# Altium schematic sheet Sheet_connectors.SchDoc
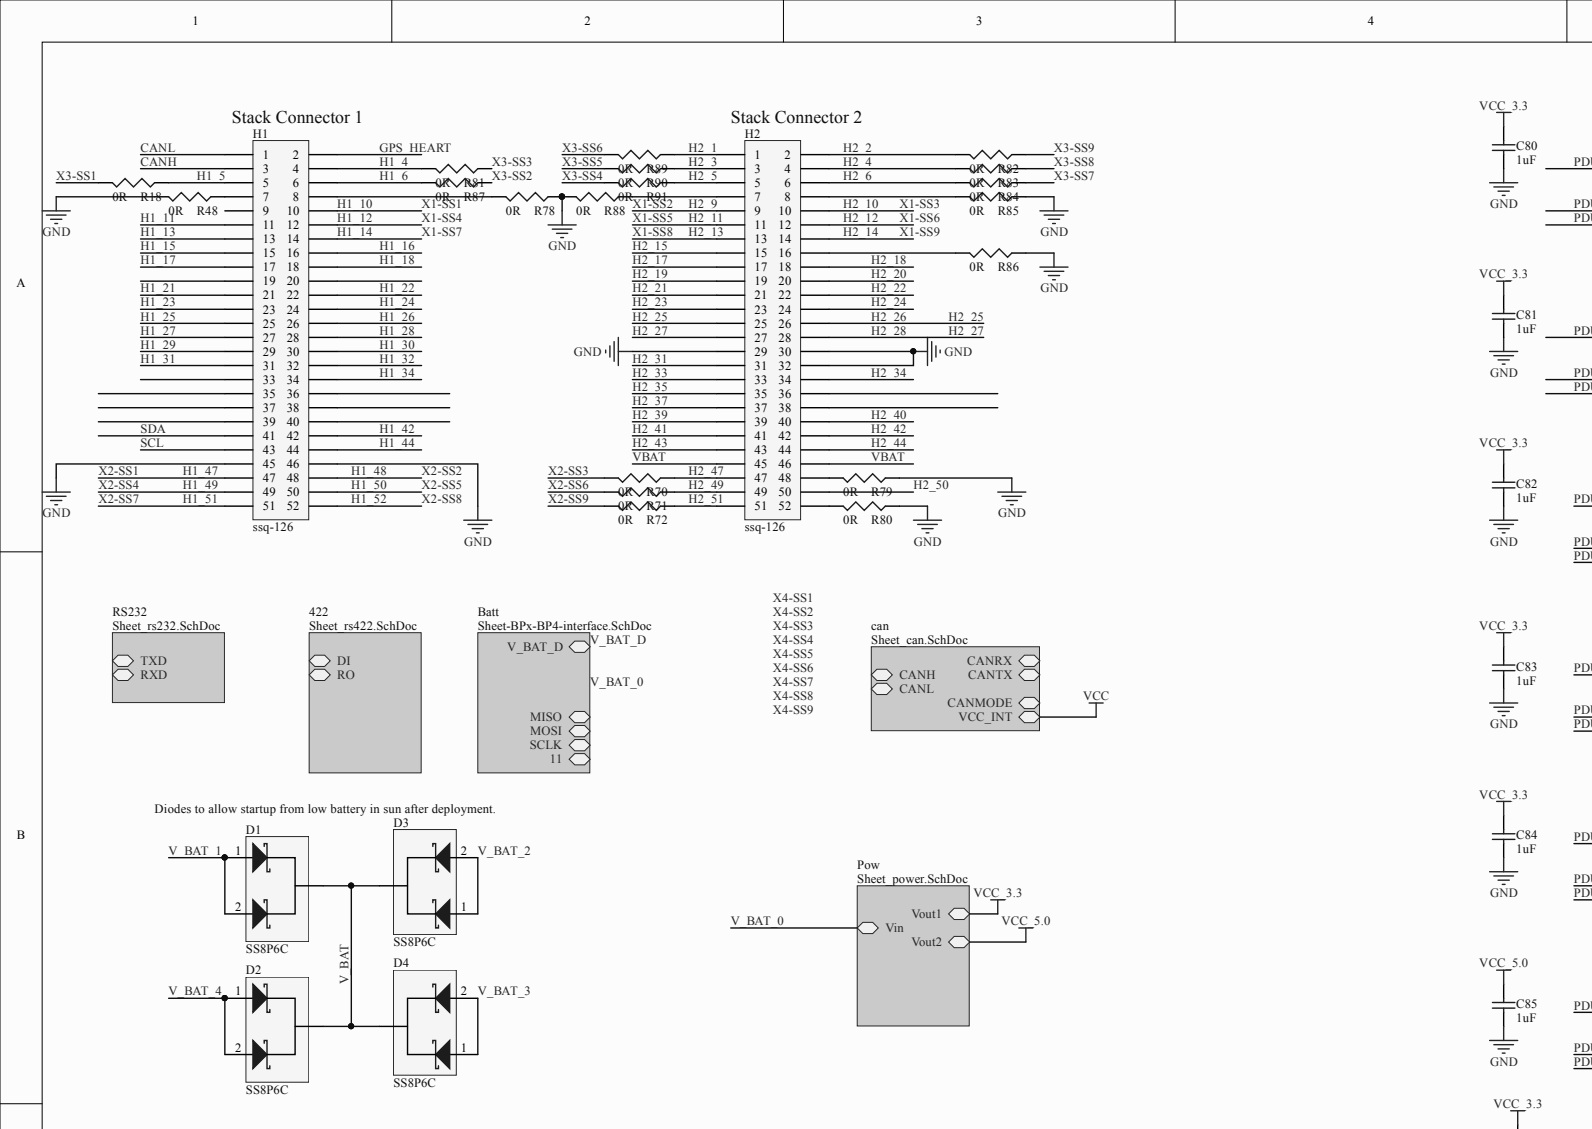
[diagram p0: Sheet_connectors.SchDoc, top left]
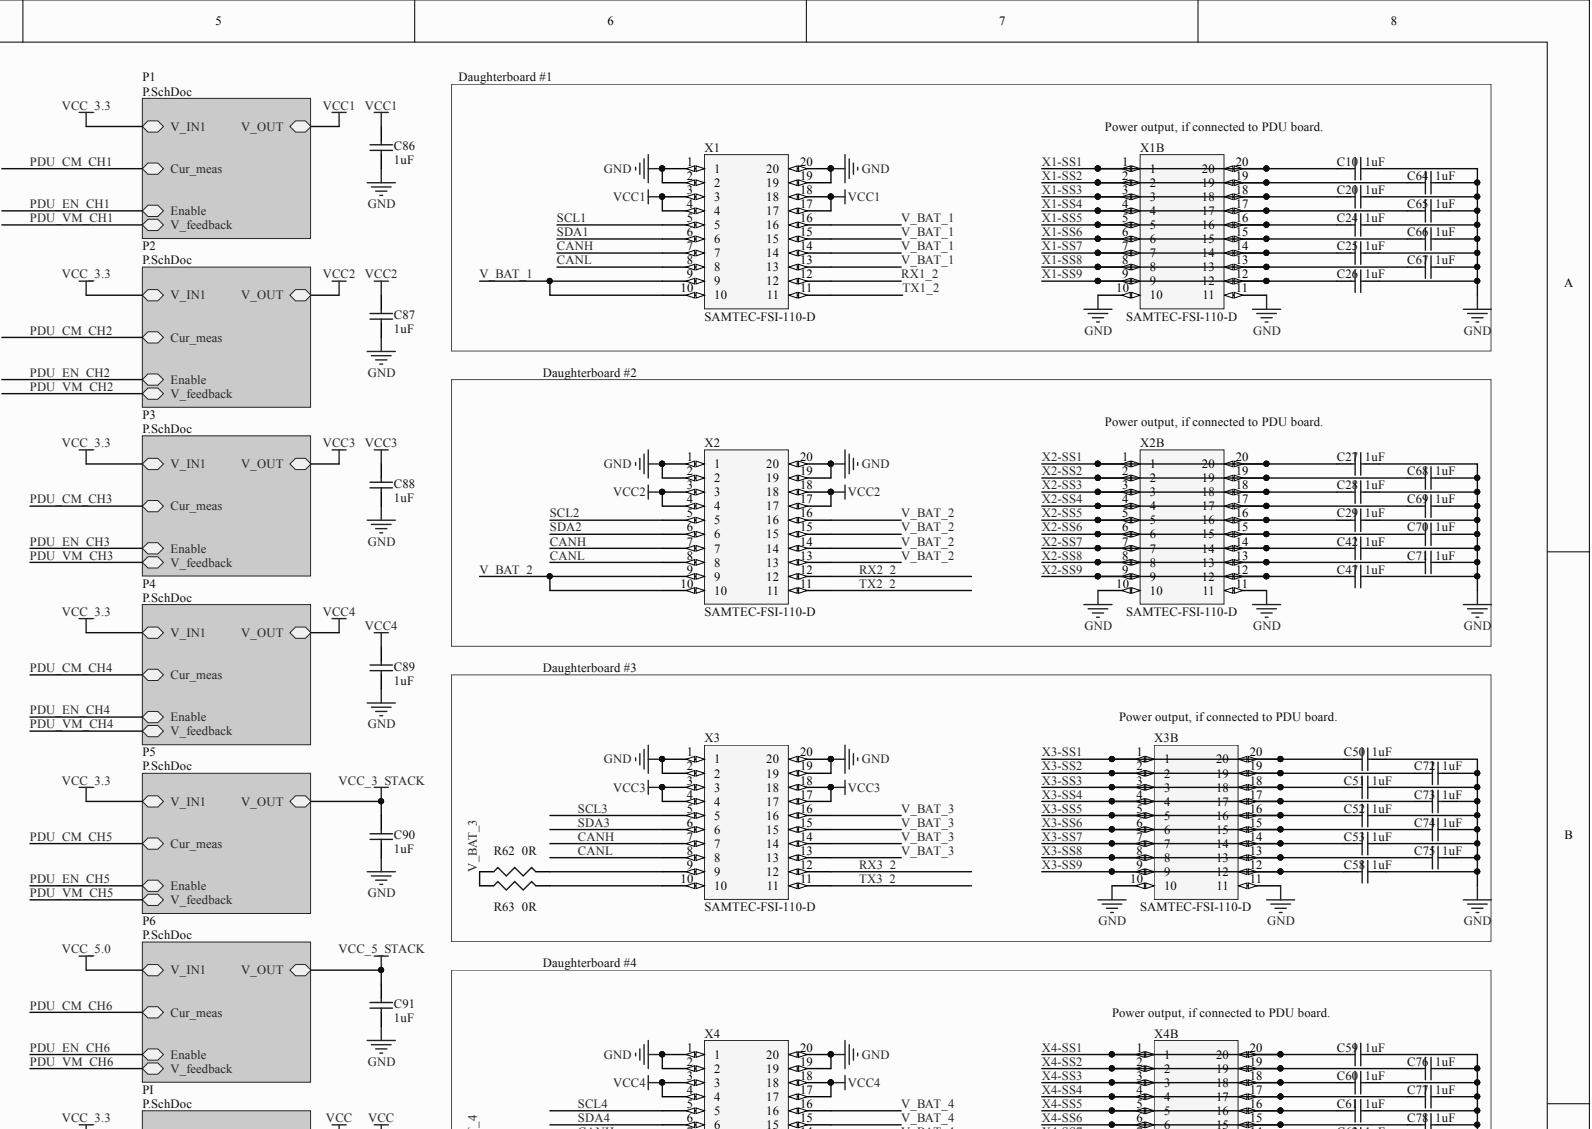
[diagram p1: Sheet_connectors.SchDoc, top right]
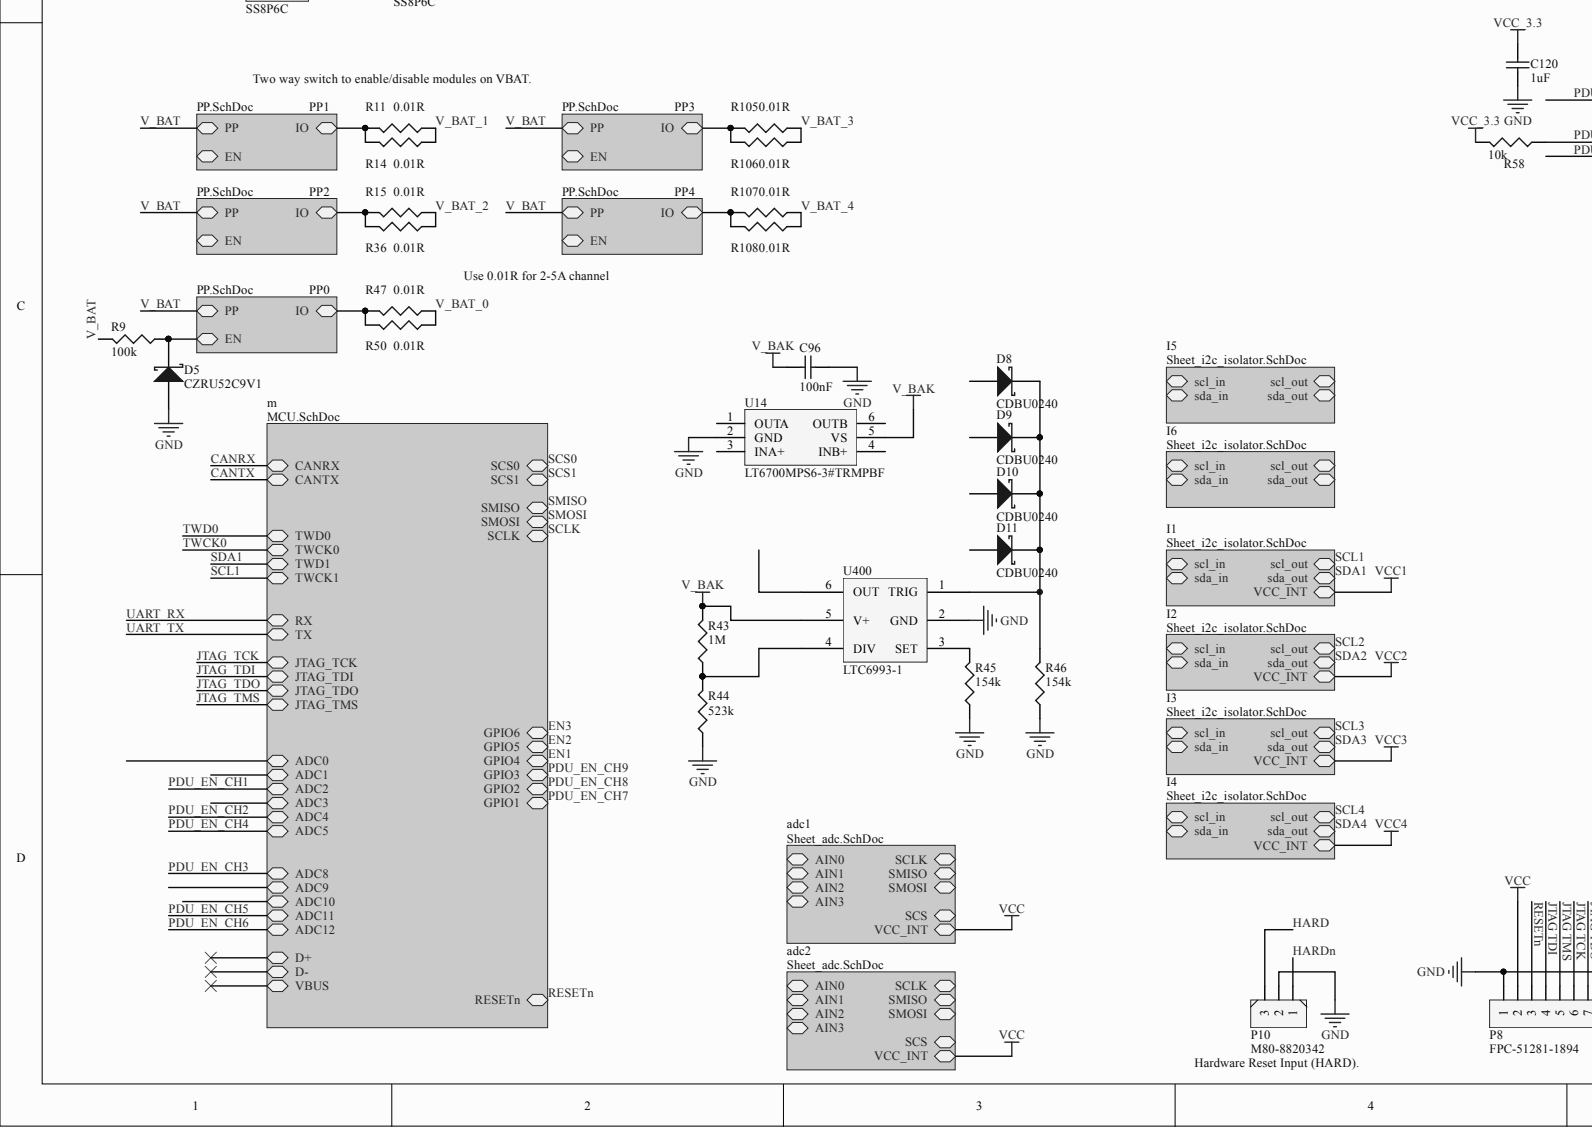
[diagram p2: Sheet_connectors.SchDoc, bottom left]
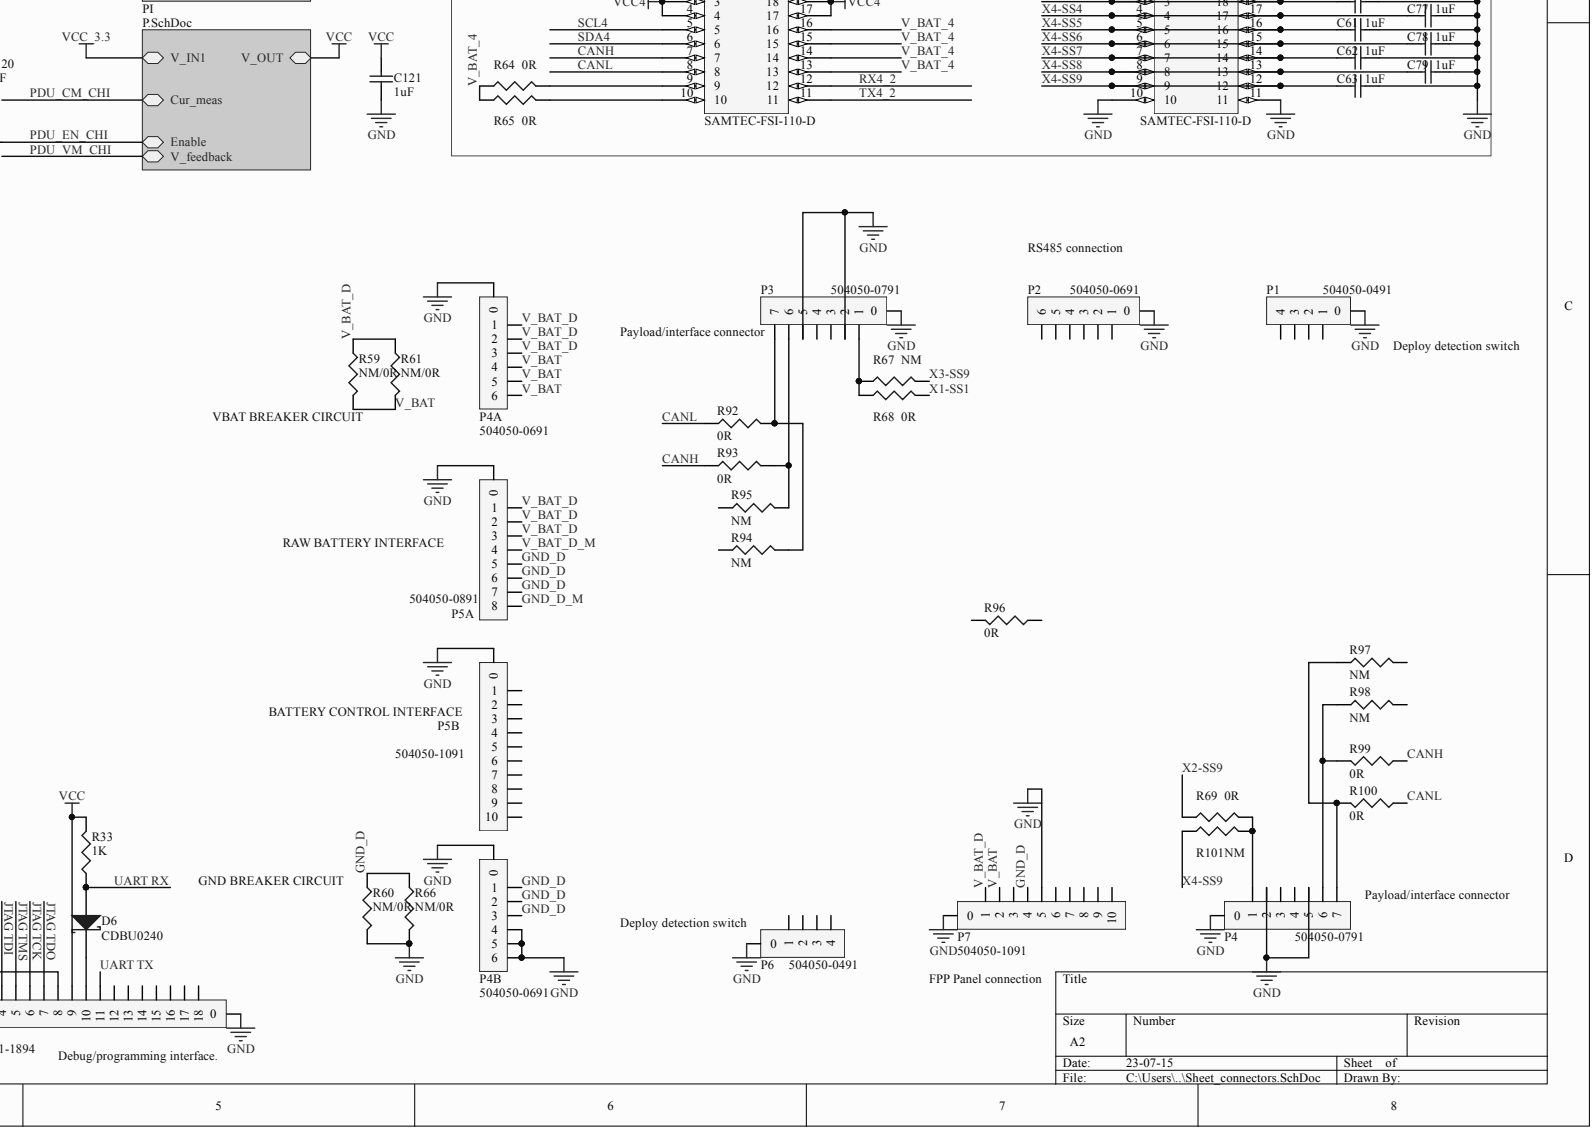
[diagram p3: Sheet_connectors.SchDoc, bottom right]

## Parts
C10  value 1uF  [0805]  (hidden comment: Cap Semi)
C20  value 1uF  [0805]  (hidden comment: Cap Semi)
C24  value 1uF  [0805]  (hidden comment: Cap Semi)
C25  value 1uF  [0805]  (hidden comment: Cap Semi)
C26  value 1uF  [0805]  (hidden comment: Cap Semi)
C27  value 1uF  [0805]  (hidden comment: Cap Semi)
C28  value 1uF  [0805]  (hidden comment: Cap Semi)
C29  value 1uF  [0805]  (hidden comment: Cap Semi)
C42  value 1uF  [0805]  (hidden comment: Cap Semi)
C47  value 1uF  [0805]  (hidden comment: Cap Semi)
C50  value 1uF  [0805]  (hidden comment: Cap Semi)
C51  value 1uF  [0805]  (hidden comment: Cap Semi)
C52  value 1uF  [0805]  (hidden comment: Cap Semi)
C53  value 1uF  [0805]  (hidden comment: Cap Semi)
C58  value 1uF  [0805]  (hidden comment: Cap Semi)
C59  value 1uF  [0805]  (hidden comment: Cap Semi)
C60  value 1uF  [0805]  (hidden comment: Cap Semi)
C61  value 1uF  [0805]  (hidden comment: Cap Semi)
C62  value 1uF  [0805]  (hidden comment: Cap Semi)
C63  value 1uF  [0805]  (hidden comment: Cap Semi)
C64  value 1uF  [0805]  (hidden comment: Cap Semi)
C65  value 1uF  [0805]  (hidden comment: Cap Semi)
C66  value 1uF  [0805]  (hidden comment: Cap Semi)
C67  value 1uF  [0805]  (hidden comment: Cap Semi)
C68  value 1uF  [0805]  (hidden comment: Cap Semi)
C69  value 1uF  [0805]  (hidden comment: Cap Semi)
C70  value 1uF  [0805]  (hidden comment: Cap Semi)
C71  value 1uF  [0805]  (hidden comment: Cap Semi)
C72  value 1uF  [0805]  (hidden comment: Cap Semi)
C73  value 1uF  [0805]  (hidden comment: Cap Semi)
C74  value 1uF  [0805]  (hidden comment: Cap Semi)
C75  value 1uF  [0805]  (hidden comment: Cap Semi)
C76  value 1uF  [0805]  (hidden comment: Cap Semi)
C77  value 1uF  [0805]  (hidden comment: Cap Semi)
C78  value 1uF  [0805]  (hidden comment: Cap Semi)
C79  value 1uF  [0805]  (hidden comment: Cap Semi)
C80  value 1uF  [0805]  (hidden comment: Cap Semi)
C81  value 1uF  [0805]  (hidden comment: Cap Semi)
C82  value 1uF  [0805]  (hidden comment: Cap Semi)
C83  value 1uF  [0805]  (hidden comment: Cap Semi)
C84  value 1uF  [0805]  (hidden comment: Cap Semi)
C85  value 1uF  [0805]  (hidden comment: Cap Semi)
C86  value 1uF  [0805]  (hidden comment: Cap Semi)
C87  value 1uF  [0805]  (hidden comment: Cap Semi)
C88  value 1uF  [0805]  (hidden comment: Cap Semi)
C89  value 1uF  [0805]  (hidden comment: Cap Semi)
C90  value 1uF  [0805]  (hidden comment: Cap Semi)
C91  value 1uF  [0805]  (hidden comment: Cap Semi)
C96  value 100nF  [0402]  (hidden comment: Cap Semi)
C120  value 1uF  [0805]  (hidden comment: Cap Semi)
C121  value 1uF  [0805]  (hidden comment: Cap Semi)
D1  SS8P6C  [TO-227A(smpc)]
D2  SS8P6C  [TO-227A(smpc)]
D3  SS8P6C  [TO-227A(smpc)]
D4  SS8P6C  [TO-227A(smpc)]
D5  CZRU52C9V1  [CD1608-0603]
D6  CDBU0240  [CD1608-0603]
D8  CDBU0240  [CD1608-0603]
D9  CDBU0240  [CD1608-0603]
D10  CDBU0240  [CD1608-0603]
D11  CDBU0240  [CD1608-0603]
H1  ssq-126  [footprint 2x26 stik]
H2  ssq-126  [footprint 2x26 stik]
P1  504050-0491  [pico-lock-04]
P2  504050-0691  [pico-lock-06]
P3  504050-0791  [pico-lock-07]
P4  504050-0791  [pico-lock-07]
P4A  504050-0691  [pico-lock-06]
P4B  504050-0691  [pico-lock-06]
P5A  504050-0891  [pico-lock-08-W]
P5B  504050-1091  [pico-lock-10]
P6  504050-0491  [pico-lock-04]
P7  504050-1091  [pico-lock-10]
P8  FPC-51281-1894  [51281-1894]
P10  M80-8820342  [M80-8820342]
R9  value 100k  [0402]  (hidden comment: Res3)
R11  value 0.01R  [0603]  (hidden comment: Res3)
R14  value 0.01R  [0603]  (hidden comment: Res3)
R15  value 0.01R  [0603]  (hidden comment: Res3)
R18  value 0R  [0505]  (hidden comment: Res3)
R33  value 1K  [0402]  (hidden comment: Res3)
R36  value 0.01R  [0603]  (hidden comment: Res3)
R43  value 1M  [0402]  (hidden comment: Res3)
R44  value 523k  [0402]  (hidden comment: Res3)
R45  value 154k  [0402]  (hidden comment: Res3)
R46  value 154k  [0402]  (hidden comment: Res3)
R47  value 0.01R  [0603]  (hidden comment: Res3)
R48  value 0R  [0505]  (hidden comment: Res3)
R50  value 0.01R  [0603]  (hidden comment: Res3)
R58  value 10k  [0402]  (hidden comment: Res3)
R59  value NM/0R  [0505]  (hidden comment: Res3)
R60  value NM/0R  [0505]  (hidden comment: Res3)
R61  value NM/0R  [0505]  (hidden comment: Res3)
R62  value 0R  [0505]  (hidden comment: Res3)
R63  value 0R  [0505]  (hidden comment: Res3)
R64  value 0R  [0505]  (hidden comment: Res3)
R65  value 0R  [0505]  (hidden comment: Res3)
R66  value NM/0R  [0505]  (hidden comment: Res3)
R67  value NM  [0505]  (hidden comment: Res3)
R68  value 0R  [0505]  (hidden comment: Res3)
R69  value 0R  [0505]  (hidden comment: Res3)
R70  Res3  [0505]  (value not drawn)
R71  value 0R  [0505]  (hidden comment: Res3)
R72  value 0R  [0505]  (hidden comment: Res3)
R78  value 0R  [0505]  (hidden comment: Res3)
R79  value 0R  [0505]  (hidden comment: Res3)
R80  value 0R  [0505]  (hidden comment: Res3)
R81  value 0R  [0505]  (hidden comment: Res3)
R82  value 0R  [0505]  (hidden comment: Res3)
R83  value 0R  [0505]  (hidden comment: Res3)
R84  value 0R  [0505]  (hidden comment: Res3)
R85  value 0R  [0505]  (hidden comment: Res3)
R86  value 0R  [0505]  (hidden comment: Res3)
R87  value 0R  [0505]  (hidden comment: Res3)
R88  value 0R  [0505]  (hidden comment: Res3)
R89  value 0R  [0505]  (hidden comment: Res3)
R90  value 0R  [0505]  (hidden comment: Res3)
R91  value 0R  [0505]  (hidden comment: Res3)
R92  value 0R  [0402]  (hidden comment: Res3)
R93  value 0R  [0402]  (hidden comment: Res3)
R94  value NM  [0402]  (hidden comment: Res3)
R95  value NM  [0402]  (hidden comment: Res3)
R96  value 0R  [0402]  (hidden comment: Res3)
R97  value NM  [0402]  (hidden comment: Res3)
R98  value NM  [0402]  (hidden comment: Res3)
R99  value 0R  [0402]  (hidden comment: Res3)
R100  value 0R  [0402]  (hidden comment: Res3)
R101  value NM  [0505]  (hidden comment: Res3)
R105  value 0.01R  [0603]  (hidden comment: Res3)
R106  value 0.01R  [0603]  (hidden comment: Res3)
R107  value 0.01R  [0603]  (hidden comment: Res3)
R108  value 0.01R  [0603]  (hidden comment: Res3)
U14  LT6700  PartNumber: LT6700MPS6-3#TRMPBF  [SOT23-6]
U400  LTC6993-1  [SOT95P280X100-6N]
X1  SAMTEC-FSI-110-D  [FSI-110-03-G-D-AD]
X1B  SAMTEC-FSI-110-D  [FSI-110-03-G-D-AD]
X2  SAMTEC-FSI-110-D  [FSI-110-03-G-D-AD]
X2B  SAMTEC-FSI-110-D  [FSI-110-03-G-D-AD]
X3  SAMTEC-FSI-110-D  [FSI-110-03-G-D-AD]
X3B  SAMTEC-FSI-110-D  [FSI-110-03-G-D-AD]
X4  SAMTEC-FSI-110-D  [FSI-110-03-G-D-AD]
X4B  SAMTEC-FSI-110-D  [FSI-110-03-G-D-AD]

## Nets
GND: C10.1 C20.1 C24.1 C25.1 C26.1 C27.1 C28.1 C29.1 C42.1 C47.1 C50.1 C51.1 C52.1 C53.1 C58.1 C59.1 C60.1 C61.1 C62.1 C63.1 C64.1 C65.1 C66.1 C67.1 C68.1 C69.1 C70.1 C71.1 C72.1 C73.1 C74.1 C75.1 C76.1 C77.1 C78.1 C79.1 C80.1 C81.1 C82.1 C83.1 C84.1 C85.1 C86.1 C87.1 C88.1 C89.1 C90.1 C91.1 C96.1 C120.1 C121.1 D5.1 H1.45 H1.46 H2.29 H2.30 H2.32 P1.0 P2.0 P3.0 P3.2 P3.5 P4.0 P4.2 P4.5 P4A.0 P4B.0 P4B.4 P4B.5 P4B.6 P5A.0 P5B.0 P6.0 P7.0 P7.5 P8.0 P8.1 P8.8 P10.2 R44.1 R45.1 R46.1 R48.2 R60.2 R66.2 R78.1 R79.1 R80.1 R85.1 R86.1 R88.2 U14.2(GND) U400.2(GND) X1.1 X1.2 X1.19 X1.20 X1B.10 X1B.11 X2.1 X2.2 X2.19 X2.20 X2B.10 X2B.11 X3.1 X3.2 X3.19 X3.20 X3B.10 X3B.11 X4.1 X4.2 X4.19 X4.20 X4B.10 X4B.11
V_BAK: C96.2 R43.2 U14.5(VS) U400.5(V+)
VCC: C121.2 P8.2 P8.9 R33.2
VCC1: C86.2 X1.3 X1.4 X1.17 X1.18
VCC2: C87.2 X2.3 X2.4 X2.17 X2.18
VCC3: C88.2 X3.3 X3.4 X3.17 X3.18
VCC4: C89.2 X4.3 X4.4 X4.17 X4.18
VCC_3.3: C80.2 C81.2 C82.2 C83.2 C84.2 C120.2 R58.2
VCC_3_STACK: C90.2
VCC_5.0: C85.2
VCC_5_STACK: C91.2
CANH: H1.3 R93.1 R99.1 X1.7 X2.7 X3.7 X4.7
CANL: H1.1 R92.1 R100.1 X1.8 X2.8 X3.8 X4.8
GND_D: P4B.1 P4B.2 P4B.3 P5A.5 P5A.6 P5A.7 P7.4 R60.1 R66.1
GND_D_M: P5A.8
GPS_HEART: H1.2
H1_4: H1.4 R81.2
H1_5: H1.5 R18.1
H1_6: H1.6 R87.2
H1_10: C10.2 H1.10 R68.2 X1B.1 X1B.20
H1_11: H1.11
H1_12: C65.2 H1.12 X1B.4 X1B.17
H1_13: H1.13
H1_14: C25.2 H1.14 X1B.7 X1B.14
H1_15: H1.15
H1_16: H1.16
H1_17: H1.17
H1_18: H1.18
H1_21: H1.21
H1_22: H1.22
H1_23: H1.23
H1_24: H1.24
H1_25: H1.25
H1_26: H1.26
H1_27: H1.27
H1_28: H1.28
H1_29: H1.29
H1_30: H1.30
H1_31: H1.31
H1_32: H1.32
H1_34: H1.34
H1_42: H1.42
H1_44: H1.44
H1_47: C27.2 H1.47 X2B.1 X2B.20
H1_48: C68.2 H1.48 X2B.2 X2B.19
H1_49: C69.2 H1.49 X2B.4 X2B.17
H1_50: C29.2 H1.50 X2B.5 X2B.16
H1_51: C42.2 H1.51 X2B.7 X2B.14
H1_52: C71.2 H1.52 X2B.8 X2B.13
H2_1: H2.1 R89.1
H2_2: H2.2 R82.2
H2_3: H2.3 R90.1
H2_4: H2.4 R83.2
H2_5: H2.5 R91.1
H2_6: H2.6 R84.2
H2_9: C64.2 H2.9 X1B.2 X1B.19
H2_10: C20.2 H2.10 X1B.3 X1B.18
H2_11: C24.2 H2.11 X1B.5 X1B.16
H2_12: C66.2 H2.12 X1B.6 X1B.15
H2_13: C67.2 H2.13 X1B.8 X1B.13
H2_14: C26.2 H2.14 X1B.9 X1B.12
H2_15: H2.15
H2_17: H2.17
H2_18: H2.18
H2_19: H2.19
H2_20: H2.20
H2_21: H2.21
H2_22: H2.22
H2_23: H2.23
H2_24: H2.24
H2_25: H2.25 H2.26
H2_27: H2.27 H2.28
H2_31: H2.31
H2_33: H2.33
H2_34: H2.34
H2_35: H2.35
H2_37: H2.37
H2_39: H2.39
H2_40: H2.40
H2_41: H2.41
H2_42: H2.42
H2_43: H2.43
H2_44: H2.44
H2_47: H2.47 R70.1
H2_49: H2.49 R71.1
H2_50: H2.50
H2_51: H2.51 R72.1
HARD: P10.3
HARDN: P10.1
JTAG TCK: P8.6
JTAG TDI: P8.4
JTAG TDO: P8.7
JTAG TMS: P8.5
PDU_EN_CHI: R58.1
RESETN: P8.3
RX1_2: X1.12
RX2_2: X2.12
RX3_2: X3.12
RX4_2: X4.12
SCL: H1.43
SCL1: X1.5
SCL2: X2.5
SCL3: X3.5
SCL4: X4.5
SDA: H1.41
SDA1: X1.6
SDA2: X2.6
SDA3: X3.6
SDA4: X4.6
TX1_2: X1.11
TX2_2: X2.11
TX3_2: X3.11
TX4_2: X4.11
UART RX: D6.1 R33.1
UART TX: P8.11
V_BAT: D1.3 D2.3 D3.3 D4.3 P4A.4 P4A.5 P4A.6 P7.2 R9.2 R59.2 R61.2
V_BAT_0: R47.1 R50.1
V_BAT_1: D1.1 D1.2 R11.1 R14.1 X1.9 X1.10 X1.13 X1.14 X1.15 X1.16
V_BAT_2: D3.1 D3.2 R15.1 R36.1 X2.9 X2.10 X2.13 X2.14 X2.15 X2.16
V_BAT_3: D4.1 D4.2 R62.1 R63.1 R105.1 R106.1 X3.13 X3.14 X3.15 X3.16
V_BAT_4: D2.1 D2.2 R64.1 R65.1 R107.1 R108.1 X4.13 X4.14 X4.15 X4.16
V_BAT_D: P4A.1 P4A.2 P4A.3 P5A.1 P5A.2 P5A.3 P7.1 R59.1 R61.1
V_BAT_D_M: P5A.4
VBAT: H2.45 H2.46
X2-SS3: C28.2 R70.2 X2B.3 X2B.18
X2-SS6: C70.2 R71.2 X2B.6 X2B.15
X2-SS9: C47.2 R69.1 R72.2 X2B.9 X2B.12
X3-SS1: C50.2 R18.2 X3B.1 X3B.20
X3-SS2: C72.2 R87.1 X3B.2 X3B.19
X3-SS3: C51.2 R81.1 X3B.3 X3B.18
X3-SS4: C73.2 R91.2 X3B.4 X3B.17
X3-SS5: C52.2 R90.2 X3B.5 X3B.16
X3-SS6: C74.2 R89.2 X3B.6 X3B.15
X3-SS7: C53.2 R84.1 X3B.7 X3B.14
X3-SS8: C75.2 R83.1 X3B.8 X3B.13
X3-SS9: C58.2 R67.2 R82.1 X3B.9 X3B.12
X4-SS1: C59.2 X4B.1 X4B.20
X4-SS2: C76.2 X4B.2 X4B.19
X4-SS3: C60.2 X4B.3 X4B.18
X4-SS4: C77.2 X4B.4 X4B.17
X4-SS5: C61.2 X4B.5 X4B.16
X4-SS6: C78.2 X4B.6 X4B.15
X4-SS7: C62.2 X4B.7 X4B.14
X4-SS8: C79.2 X4B.8 X4B.13
X4-SS9: C63.2 R101.1 X4B.9 X4B.12
NetD5_2: D5.2 R9.1
NetD6_2: D6.2 P8.10
NetD8_1: D8.1
NetD8_2: D8.2 D9.2 D10.2 D11.2 R46.2 U400.1(TRIG)
NetD9_1: D9.1
NetD10_1: D10.1
NetD11_1: D11.1
NetH1_7: H1.7 R48.1
NetH1_8: H1.8 R78.2
NetH1_9: H1.9
NetH1_19: H1.19
NetH1_20: H1.20
NetH1_33: H1.33
NetH1_35: H1.35
NetH1_36: H1.36
NetH1_37: H1.37
NetH1_38: H1.38
NetH1_39: H1.39
NetH1_40: H1.40
NetH2_7: H2.7 R88.1
NetH2_8: H2.8 R85.2
NetH2_16: H2.16 R86.2
NetH2_36: H2.36
NetH2_38: H2.38
NetH2_48: H2.48 R79.2
NetH2_52: H2.52 R80.2
NetP1_1: P1.1
NetP1_2: P1.2
NetP1_3: P1.3
NetP1_4: P1.4
NetP2_1: P2.1
NetP2_2: P2.2
NetP2_3: P2.3
NetP2_4: P2.4
NetP2_5: P2.5
NetP2_6: P2.6
NetP3_1: P3.1 R67.1 R68.1
NetP3_3: P3.3
NetP3_4: P3.4
NetP3_6: P3.6 R93.2 R95.2
NetP3_7: P3.7 R92.2 R94.2
NetP4_1: P4.1 R69.2 R101.2
NetP4_3: P4.3
NetP4_4: P4.4
NetP4_6: P4.6 R98.1 R99.2
NetP4_7: P4.7 R97.1 R100.2
NetP5B_1: P5B.1
NetP5B_2: P5B.2
NetP5B_3: P5B.3
NetP5B_4: P5B.4
NetP5B_5: P5B.5
NetP5B_6: P5B.6
NetP5B_7: P5B.7
NetP5B_8: P5B.8
NetP5B_9: P5B.9
NetP5B_10: P5B.10
NetP6_1: P6.1
NetP6_2: P6.2
NetP6_3: P6.3
NetP6_4: P6.4
NetP7_3: P7.3
NetP7_6: P7.6
NetP7_7: P7.7
NetP7_8: P7.8
NetP7_9: P7.9
NetP7_10: P7.10
NetP8_12: P8.12
NetP8_13: P8.13
NetP8_14: P8.14
NetP8_15: P8.15
NetP8_16: P8.16
NetP8_17: P8.17
NetP8_18: P8.18
NetR11_2: R11.2 R14.2
NetR15_2: R15.2 R36.2
NetR43_1: R43.1 R44.2 U400.4(DIV)
NetR45_2: R45.2 U400.3(SET)
NetR47_2: R47.2 R50.2
NetR62_2: R62.2 X3.9
NetR63_2: R63.2 X3.10
NetR64_2: R64.2 X4.9
NetR65_2: R65.2 X4.10
NetR94_1: R94.1
NetR95_1: R95.1
NetR96_1: R96.1
NetR96_2: R96.2
NetR97_2: R97.2
NetR98_2: R98.2
NetR105_2: R105.2 R106.2
NetR107_2: R107.2 R108.2
NetU14_1: U14.1(OUTA)
NetU14_3: U14.3(INA+)
NetU14_4: U14.4(INB+)
NetU14_6: U14.6(OUTB)
NetU400_6: U400.6(OUT)
11:
ADC0:
ADC1:
ADC3:
ADC9:
ADC10:
AIN0:
AIN1:
AIN2:
AIN3:
CANMODE:
CANRX:
CANTX:
D+:
D-:
DI:
EN:
EN1:
EN2:
EN3:
JTAG_TCK:
JTAG_TDI:
JTAG_TDO:
JTAG_TMS:
MISO:
MOSI:
PDU_CM_CH1:
PDU_CM_CH2:
PDU_CM_CH3:
PDU_CM_CH4:
PDU_CM_CH5:
PDU_CM_CH6:
PDU_CM_CHI:
PDU_EN_CH1:
PDU_EN_CH2:
PDU_EN_CH3:
PDU_EN_CH4:
PDU_EN_CH5:
PDU_EN_CH6:
PDU_EN_CH7:
PDU_EN_CH8:
PDU_EN_CH9:
PDU_VM_CH1:
PDU_VM_CH2:
PDU_VM_CH3:
PDU_VM_CH4:
PDU_VM_CH5:
PDU_VM_CH6:
PDU_VM_CHI:
RO:
RXD:
SCL_IN:
SCL_OUT:
SCLK:
SCS:
SCS0:
SCS1:
SDA_IN:
SDA_OUT:
SMISO:
SMOSI:
TWCK0:
TWD0:
TXD:
UART_RX:
UART_TX:
VBUS:

## Library data of the parts
C10 C20 C24-C29 C42 C47 C50-C53 C58-C91 C120 C121 = Cap Semi: Capacitor (Semiconductor SIM Model); PartNumber: CL10B104KB85PNC; Component Kind: Standard; LatestRevisionDate: 29-May-2009; LatestRevisionNote: IPC-7351 Footprint Added.; Library Name: Miscellaneous Devices.IntLib; PackageDescription: Chip Capacitor; PackageReference: C1206; Published: 8-Jun-2000; Publisher: Altium Limited; Signal Integrity: Cap Semi; Simulation: CAP
C96 = Cap Semi: Capacitor (Semiconductor SIM Model); Component Kind: Standard; LatestRevisionDate: 29-May-2009; LatestRevisionNote: IPC-7351 Footprint Added.; Library Name: Miscellaneous Devices.IntLib; PackageDescription: Chip Capacitor; PackageReference: C1206; Published: 8-Jun-2000; Publisher: Altium Limited; Signal Integrity: Cap Semi; Simulation: CAP
D5 D6 D8-D11 = D Schottky: Schottky Diode; Component Kind: Standard; Library Name: Miscellaneous Devices.IntLib; Signal Integrity: D Schottky; Simulation: SKYDIODE
  D5: PartNumber: CZRU52C9V1
  D6 D8-D11: Code_JEDEC: DO-214-AA; LatestRevisionDate: 17-Jul-2002; LatestRevisionNote: Re-released for DXP Platform.; PackageReference: SMB; Published: 8-Jun-2000; Publisher: Altium Limited
H1 H2 = Header 26X2: Header, 26-Pin, Dual row; Component Kind: Standard; LatestRevisionDate: 17-Jul-2002; LatestRevisionNote: Re-released for DXP Platform.; Library Name: Vectron.SchLib; Publisher: Altium Limited; Signal Integrity: Connector
P1 P6 = 504050-0491: Molex Pico-lock 4 pin; Component Kind: Standard; Library Name: sessentas.SchLib; PartNumber: 504050-0491
P2 P4A P4B = 504050-0691: Molex Pico-lock 6 pin; Component Kind: Standard; Library Name: sessentas.SchLib; PartNumber: 504050-0691
P3 P4 = 504050-0791: Molex Pico-lock 7 pin; PartNumber: M80-8820742; Component Kind: Standard; Library Name: sessentas.SchLib
P5A = 504050-0891: Molex Pico-lock 8 pin; Component Kind: Standard; Library Name: sessentas.SchLib; PartNumber: 504050-0891
P5B P7 = 504050-1091: Molex Pico-lock 10 pin; Component Kind: Standard; Library Name: sessentas.SchLib; PartNumber: 504050-1091
P8 = FPC-51281-1894: FPC, 0.5mm pitch, Molex, 1.2mm height.; Component Kind: Standard; Library Name: sessentas.SchLib; PartNumber: 51281-1894
P10 = M80-8820342: 1 row 3 pin HARWIN M80; Component Kind: Standard; Library Name: sessentas.SchLib; PartNumber: M80-8820342
R9 R11 R14 R15 R18 R33 R36 R43-R48 R50 R58-R69 R71 R72 R78-R80 R82-R101 R105-R108 = Res3: Resistor; Component Kind: Standard; LatestRevisionDate: 29-May-2009; LatestRevisionNote: IPC-7351 Footprint Added.; Library Name: Miscellaneous Devices.SchLib; PackageDescription: Chip Resistor; PackageReference: J1-0603; Published: 8-Jun-2000; Publisher: Altium Limited; Signal Integrity: Res; Simulation: RESISTOR
R70 R81 = Res3: Resistor; Value: 0R; Component Kind: Standard; LatestRevisionDate: 29-May-2009; LatestRevisionNote: IPC-7351 Footprint Added.; Library Name: Miscellaneous Devices.SchLib; PackageDescription: Chip Resistor; PackageReference: J1-0603; Published: 8-Jun-2000; Publisher: Altium Limited; Signal Integrity: Res; Simulation: RESISTOR
U14 = LT6700: Micropower, Low Voltage,  Dual Comparator with  400mV Reference; Component Kind: Standard; Library Name: sessentas.SchLib
U400 = LTC6993: Component Kind: Standard; Library Name: linear.SchLib
D1-D4 = SS8P6C: Component Kind: Standard; Library Name: sessentas.SchLib
X1 X1B X2 X2B X3 X3B X4 X4B = SAMTEC-FSI-110-D: Component Kind: Standard; Library Name: Johan.SCHLIB
